# Altium schematic sheet SignalAdapter.SchDoc
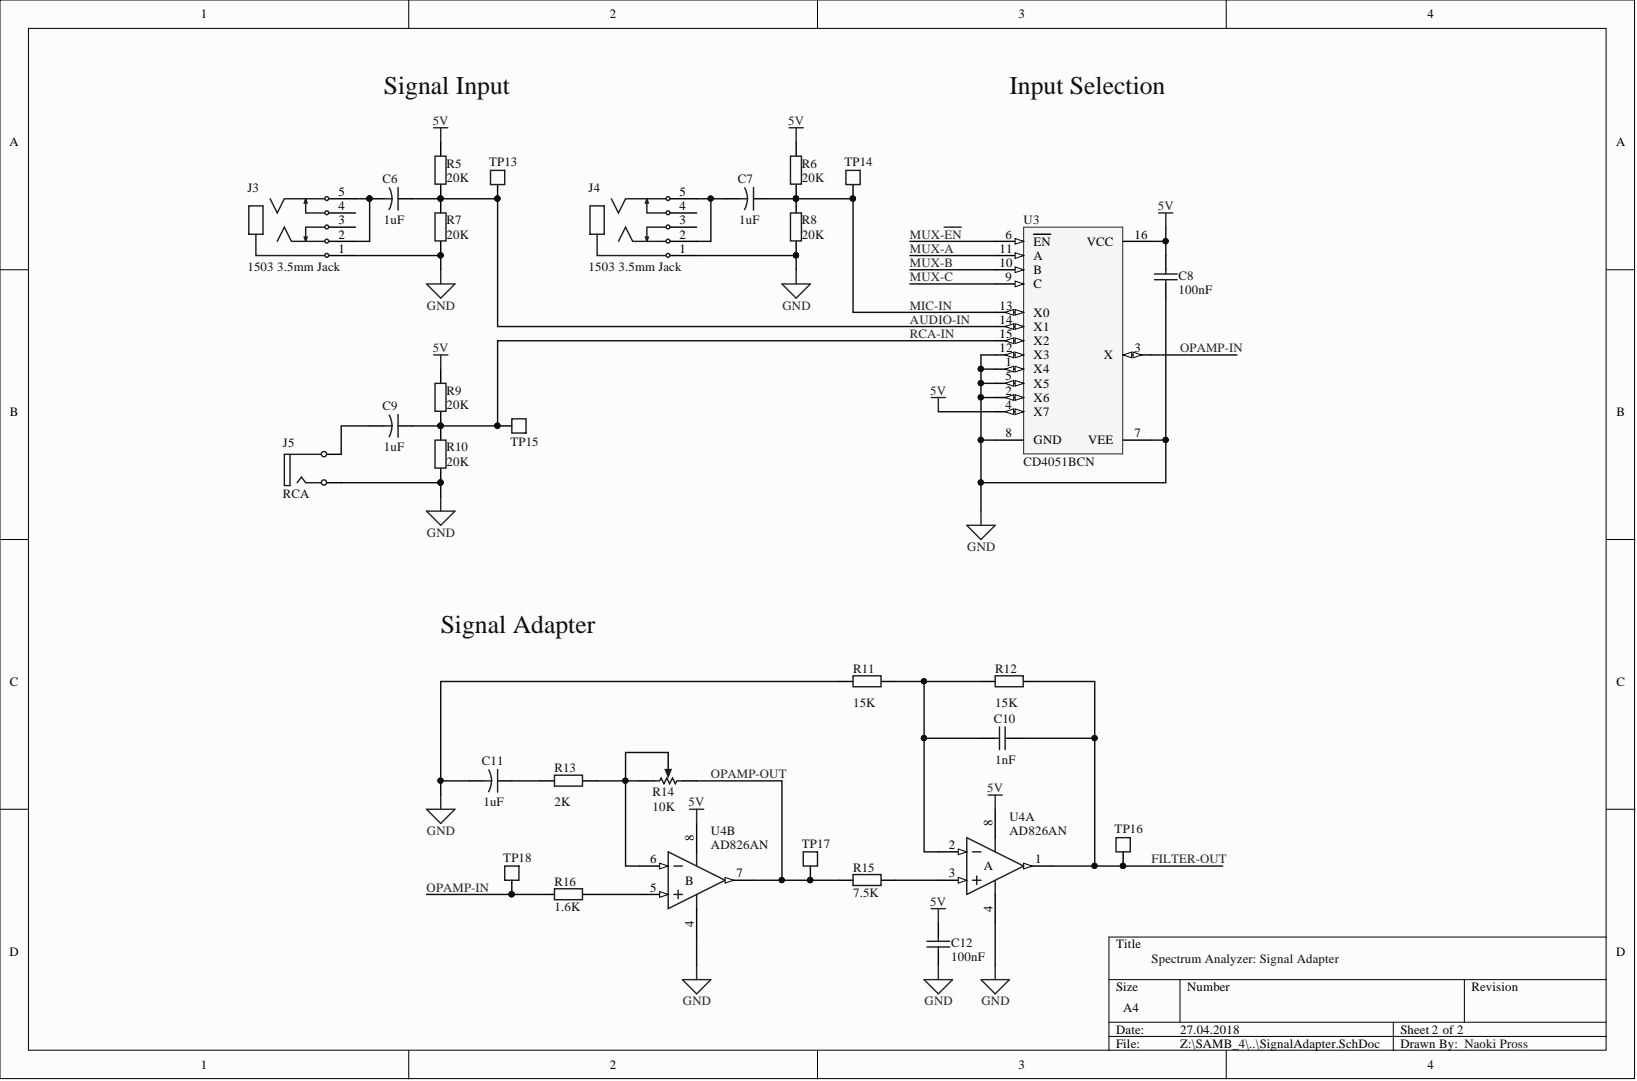
[diagram p0: SignalAdapter.SchDoc]

## Parts
C6  value 1uF  [CAPR5-4X5]  (hidden comment: Cap2)
C7  value 1uF  [CAPR5-4X5]  (hidden comment: Cap2)
C8  value 100nF  [RAD-0.1]  (hidden comment: Cap)
C9  value 1uF  [CAPR5-4X5]  (hidden comment: Cap2)
C10  value 1nF  [RAD-0.1]  (hidden comment: Cap)
C11  value 1uF  [CAPR5-4X5]  (hidden comment: Cap2)
C12  value 100nF  [RAD-0.1]  (hidden comment: Cap)
J3  1503 3.5mm Jack  [JIS C 6560]
J4  1503 3.5mm Jack  [JIS C 6560]
J5  RCA  [RC-5231]
R5  value 20K  [AXIAL-0.4]  (hidden comment: Res2)
R6  value 20K  [AXIAL-0.4]  (hidden comment: Res2)
R7  value 20K  [AXIAL-0.4]  (hidden comment: Res2)
R8  value 20K  [AXIAL-0.4]  (hidden comment: Res2)
R9  value 20K  [AXIAL-0.4]  (hidden comment: Res2)
R10  value 20K  [AXIAL-0.4]  (hidden comment: Res2)
R11  value 15K  [AXIAL-0.4]  (hidden comment: Res2)
R12  value 15K  [AXIAL-0.4]  (hidden comment: Res2)
R13  value 2K  [AXIAL-0.4]  (hidden comment: Res2)
R14  value 10K  [VR4]  (hidden comment: RPot)
R15  value 7.5K  [AXIAL-0.4]  (hidden comment: Res2)
R16  value 1.6K  [AXIAL-0.4]  (hidden comment: Res2)
TP13  Test Pad  [PIN1]  (value not drawn)
TP14  Test Pad  [PIN1]  (value not drawn)
TP15  Test Pad  [PIN1]  (value not drawn)
TP16  Test Pad  [PIN1]  (value not drawn)
TP17  Test Pad  [PIN1]  (value not drawn)
TP18  Test Pad  [PIN1]  (value not drawn)
U3  CD4051BCN  [N16E]
U4  AD826AN  [N-8]  (parts A,B)

## Nets
5V: C8.1 C12.1 R5.2 R6.2 R9.2 U3.4(X7) U3.16(VCC) U4.8
GND: C8.2 C11.2 C12.2 J3.1 J4.1 J5.2B R7.1 R8.1 R10.1 R11.1 U3.1(X4) U3.2(X6) U3.5(X5) U3.7(VEE) U3.8(GND) U3.12(X3) U4.4
AUDIO-IN: C6.1 R5.1 R7.2 TP13.1 U3.14(X1)
FILTER-OUT: C10.2 R12.2 TP16.1 U4.1
MIC-IN: C7.1 R6.1 R8.2 TP14.1 U3.13(X0)
MUX-A: U3.11(A)
MUX-B: U3.10(B)
MUX-C: U3.9(C)
MUX-~{EN}: U3.6(EN)
OPAMP-IN: R16.2 TP18.1 U3.3(X)
OPAMP-OUT: R14.1 R15.2 TP17.1 U4.7
RCA-IN: C9.1 R9.1 R10.2 TP15.1 U3.15(X2)
NetC6_2: C6.2 J3.2 J3.5
NetC7_2: C7.2 J4.2 J4.5
NetC9_2: C9.2 J5.1A
NetC10_1: C10.1 R11.2 R12.1 U4.2
NetC11_1: C11.1 R13.1
NetR13_2: R13.2 R14.2 R14.3 U4.6
NetR15_1: R15.1 U4.3
NetR16_1: R16.1 U4.5

Not connected: J3 3 4; J4 3 4

## Library data of the parts
C6 C7 C9 C11 = Cap2: Capacitor; Component Kind: Standard; Library Name: Miscellaneous Devices.IntLib; PackageDescription: Capacitor; 2 Leads; PackageReference: CAPR5-4X5; Published: 23-Sep-2002; Signal Integrity: Cap; Simulation: CAP; Publisher: Altium Limited
C8 C10 C12 = Cap: Capacitor; Component Kind: Standard; LatestRevisionDate: 17-Jul-2002; LatestRevisionNote: Re-released for DXP Platform.; Library Name: Miscellaneous Devices.IntLib; PackageDescription: Radial Cap, Thru-Hole; 2 Leads; 0.3 in Pin Spacing; PackageReference: RAD-0.3; Published: 8-Jun-2000; Signal Integrity: Cap; Simulation: CAP; Publisher: Altium Limited
J3 J4 = 1503: 1503 09/17 Jack connector; Component Kind: Standard; Library Name: lumberg-connectors.SchLib
J5 = RCA: RCA Phono Jack, Right Angle, Thru-Hole, Snap-In; DrillAndSlotSize: Corresponds to nominal value.; PCB_Layout: Complies with manufacturer's recommendation.; Component Kind: Standard; ComponentLink1Description: Manufacturer Link; ComponentLink1URL: http://www.mouser.com/kobiconn/; DatasheetDocument: 7-Jul-2000; LatestRevisionDate: 15-Jul-2004; LatestRevisionNote: Component Replaced.; Library Name: Miscellaneous Connectors.IntLib; PackageDocument: 7-Jul-2000; PackageReference: 161; Published: 15-Jul-2004; Signal Integrity: RCA; Publisher: Altium Limited
R5-R11 R13 R15 R16 = Res2: Resistor; Component Kind: Standard; LatestRevisionDate: 17-Jul-2002; LatestRevisionNote: Re-released for DXP Platform.; Library Name: Miscellaneous Devices.IntLib; PackageDescription: Axial Device, Thru-Hole; 2 Leads; 0.4 in Pin Spacing; PackageReference: AXIAL-0.4; Published: 8-Jun-2000; Signal Integrity: Res; Simulation: RESISTOR; Publisher: Altium Limited
R12 = Res2: Resistor; Value: 15K; Component Kind: Standard; LatestRevisionDate: 17-Jul-2002; LatestRevisionNote: Re-released for DXP Platform.; Library Name: Miscellaneous Devices.IntLib; PackageDescription: Axial Device, Thru-Hole; 2 Leads; 0.4 in Pin Spacing; PackageReference: AXIAL-0.4; Published: 8-Jun-2000; Signal Integrity: Res; Simulation: RESISTOR; Publisher: Altium Limited
R14 = RPot: Potentiometer; Set Position: 0.5; Sim Note: Enter the Resistance value and the set point into parameter fields.; Component Kind: Standard; LatestRevisionDate: 17-Jul-2002; LatestRevisionNote: Re-released for DXP Platform.; Library Name: Miscellaneous Devices.IntLib; PackageDescription: Variable Resistor, Thru-Hole; 3 Leads; PackageReference: VR5; Published: 8-Jun-2000; Simulation: POT; Publisher: Altium Limited
TP13-TP18 = TestPad: Component Kind: Standard; Description: Test Pad; Library Name: TestPad.SchLib
U3 = CD4051BCN: 8-Channel Analog Multiplexer/Demultiplexer; Component Kind: Standard; ComponentLink1Description: Manufacturer Link; ComponentLink1URL: http://www.fairchildsemi.com/; ComponentLink2Description: Datasheet; ComponentLink2URL: http://www.fairchildsemi.com/ds/CD%2FCD4052BC.pdf; DatasheetVersion: Jan-1999; LatestRevisionDate: 29-May-2009; LatestRevisionNote: Component Body Added.; Library Name: FSC Logic Multiplexer.IntLib; PackageDescription: DIP, 16-Pin, Row Spacing 7.62 mm, Pitch 2.54 mm; PackageReference: N16E; PackageVersion: 2000; PCB3D: N16E; Published: 17-Jul-2002; Publisher: Altium Limited
U4 = AD826AN: High-Speed, Low-Power Dual Operational Amplifier; Component Kind: Standard; ComponentLink1Description: Manufacturer Link; ComponentLink1URL: http://www.analog.com/en/prod/0,,759_786_AD826%2C00.html; ComponentLink2Description: Datasheet; ComponentLink2URL: http://www.analog.com/UploadedFiles/Data_Sheets/432952987AD826_b.pdf; DatasheetVersion: REV. A 1995; LatestRevisionDate: 04-Mar-2005; LatestRevisionNote: Stylized 3D Model Added.; Library Name: AD Operational Amplifier.IntLib; PackageDescription: DIP; 8 Leads; Row Spacing 7.62 mm; Pitch 2.54 mm; PackageReference: N-8; PackageVersion: revA; PCB3D: Q-8; Published: 8-Jun-2000; Simulation: AD826A; Publisher: Altium Limited
